# Altium schematic sheet Bluetooth.SchDoc(Bluetooth)
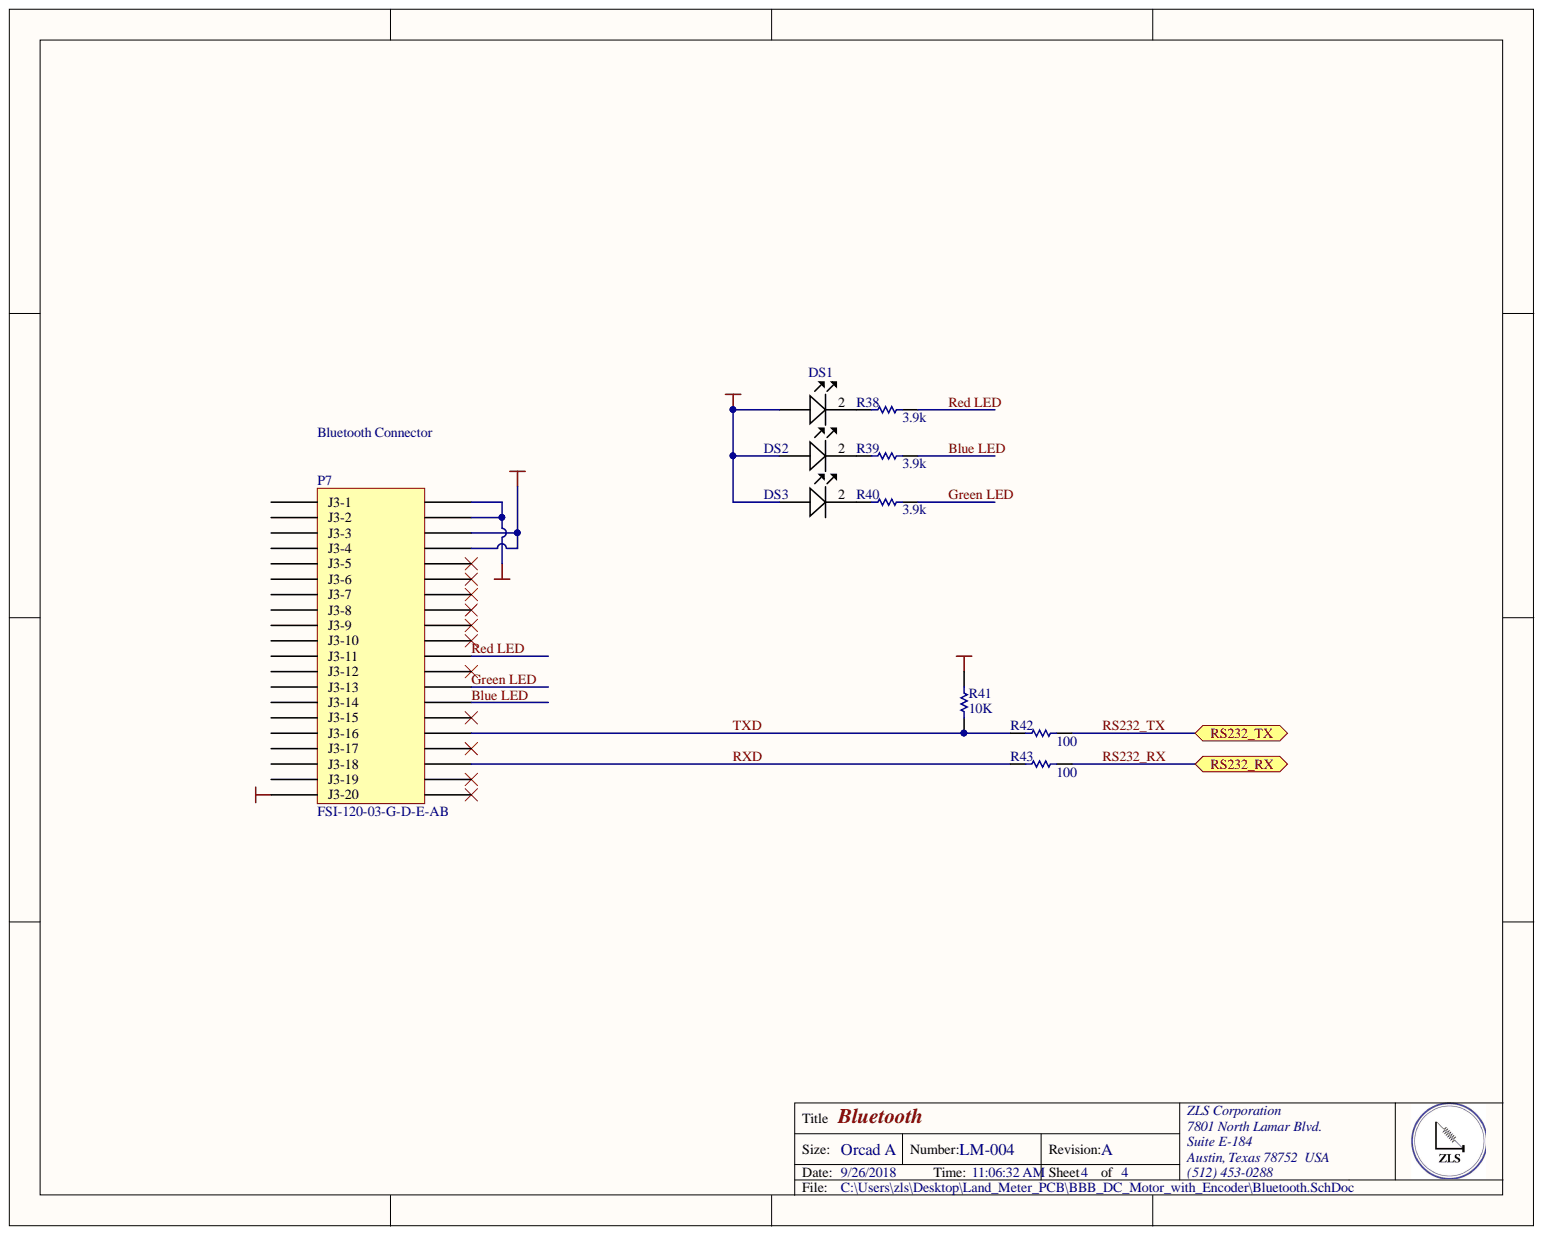
[diagram p0: Bluetooth.SchDoc]

## Parts
DS1  Lite-On LTST-S220KGKT Green LED, 571 nm 2012 (0805) Side View, Rectangle Lens SMD package  [0805C_L]  (value not drawn)
DS2  Lite-On LTST-S220KGKT Green LED, 571 nm 2012 (0805) Side View, Rectangle Lens SMD package  [0805C_L]  (value not drawn)
DS3  Lite-On LTST-S220KGKT Green LED, 571 nm 2012 (0805) Side View, Rectangle Lens SMD package  [0805C_L]  (value not drawn)
P7  FSI-120-03-G-D-E-AB  [FSI-120-03-G-D-E-AB]
R38  3.9k  [RESC0805(2012)_L]
R39  3.9k  [RESC0805(2012)_L]
R40  3.9k  [RESC0805(2012)_L]
R41  10K  [RESC0805(2012)_L]
R42  100  [RESC0805(2012)_L]
R43  100  [RESC0805(2012)_L]

## Ports
RS232_RX, RS232_TX

## Nets
+3.3: DS1.1 DS2.1 DS3.1 P7.J2-3 P7.J2-4
GND: P7.J2-1 P7.J2-2
VCC_2V85: P7.J3-20 R41.1
Blue LED: P7.J2-14 R39.1
Green LED: P7.J2-13 R40.1
Red LED: P7.J2-11 R38.1
RS232_RX: R43.1 ; port RS232_RX
RS232_TX: R42.1 ; port RS232_TX
RXD: P7.J2-18 R43.2
TXD: P7.J2-16 R41.2 R42.2
NetDS1_2: DS1.2 R38.2
NetDS2_2: DS2.2 R39.2
NetDS3_2: DS3.2 R40.2

Not connected: P7 J2-5 J2-6 J2-7 J2-8 J2-9 J2-10 J2-12 J2-15 J2-17 J2-19 J2-20 J3-1 J3-2 J3-3 J3-4 J3-5 J3-6 J3-7 J3-8 J3-9 J3-10 J3-11 J3-12 J3-13 J3-14 J3-15 J3-16 J3-17 J3-18 J3-19

## Library data of the parts
DS1-DS3 = LED A/K: Manufacturer: Vishay / Lite-On; Wavelength: 574nm; Viewing Angle: 130°; Test Current: 20mA; Power Rating: 75mW; Power Dissipation: 75W; Number of LEDs: 1; Luminous Intensity: 35mcd; Lens Transparency: Clear; Lens Style: Diffused,Colorless; Lens Colour: Colorless; Forward Voltage: 2V; Dominant Wavelength: 568nm; Width: 1mm; Supplier Part Number 2: 2062310; Supplier Part Number 1: 160-1468-1-ND; Supplier 2: Farnell; Supplier 1: Digi-Key; Stock 2: 2271; Stock 1: 74800; RoHS: YES; Radiation Hardening: No; Pricing 2: 1=0.325, 10=0.317, 100=0.23, 200=0.228, 400=0.182, 900=0.147, 3000=0.114, 9000=0.107 (GBP); Pricing 1: 1=0.29, 10=0.212, 25=0.1564, 100=0.1117, 250=0.0759, 500=0.067, 1000=0.0525 (USD); Packaging: Tape and Reel; Package Quantity: 4000; Number of Pins: 2; Number of Elements: 1; Mount: Surface Mount; Min Operating Temperature: -55°C; Max Operating Temperature: 85°C; Manufacturer Part Number: LTST-S220KGKT; Length: 2.1mm; Lead Free: Lead Free; Illumination Colour: Green; Height: 0.6mm; Forward Current: 20mA; Description: Lite-On LTST-S220KGKT Green LED, 571 nm 2012 (0805) Side View, Rectangle Lens SMD package; Depth: 0.6mm; ComponentLink8URL: http://www.farnell.com/datasheets/693084.pdf; ComponentLink8Description: http://www.farnell.com/datasheets/693084.pdf; ComponentLink7URL: http://www.farnell.com/cad/2124774.pdf; ComponentLink7Description: http://www.farnell.com/cad/2124774.pdf; ComponentLink6URL: https://datasheet.ciiva.com/16379/ltst-s220kgkt-16379082.pdf; ComponentLink6Description: https://datasheet.ciiva.com/16379/ltst-s220kgkt-16379082.pdf; ComponentLink5URL: https://datasheet.ciiva.com/2110/s-110-ltst-s220kgkt-2110071.pdf; ComponentLink5Description: https://datasheet.ciiva.com/2110/s-110-ltst-s220kgkt-2110071.pdf; ComponentLink4URL: https://datasheet.ciiva.com/12400/100-ltst-s220kgkt-59602-12400886.pdf; ComponentLink4Description: https://datasheet.ciiva.com/12400/100-ltst-s220kgkt-59602-12400886.pdf; ComponentLink3URL: https://datasheet.ciiva.com/2110/s-110-ltst-s220kgkt-2110070.pdf; ComponentLink3Description: https://datasheet.ciiva.com/2110/s-110-ltst-s220kgkt-2110070.pdf; ComponentLink2URL: https://datasheet.ciiva.com/2110/s-110-ltst-s220kgkt-2110069.pdf; ComponentLink2Description: https://datasheet.ciiva.com/2110/s-110-ltst-s220kgkt-2110069.pdf; ComponentLink1URL: https://datasheet.ciiva.com/11775/0900766b80dc0b70-11775441.pdf; ComponentLink1Description: https://datasheet.ciiva.com/11775/0900766b80dc0b70-11775441.pdf; Component Kind: Standard; Colour: Green; Case Code (Metric): 2012; Case Code (Imperial): 0805; Case/Package: 0805
R38-R40 = CMP-1013-00215-1: 2R55 0.125W 1% 0805 (2012 Metric)  SMD; Case-Metric: 2012; Case-EIA: 0805; Value: 3.9k; Tolerance: 1 %; Technology: SMT; Power: 0.125 W; Library Name: Altium Content Vault; Component Kind: Standard
R41-R43 = CMP-1013-00215-1: 2R55 0.125W 1% 0805 (2012 Metric)  SMD; Value: 3.9k; Case-Metric: 2012; Case-EIA: 0805; Tolerance: 1 %; Technology: SMT; Power: 0.125 W; Library Name: Altium Content Vault; Component Kind: Standard
P7 = FSI-120-03-G-D-E-AB: Supplier Part Number 1: FSI-120-03-G-D-E-AB; Supplier 1: Avnet; Component Kind: Standard; Library Name: ZLS_Schematic_lib.SchLib
